# Altium schematic sheet Main.SchDoc
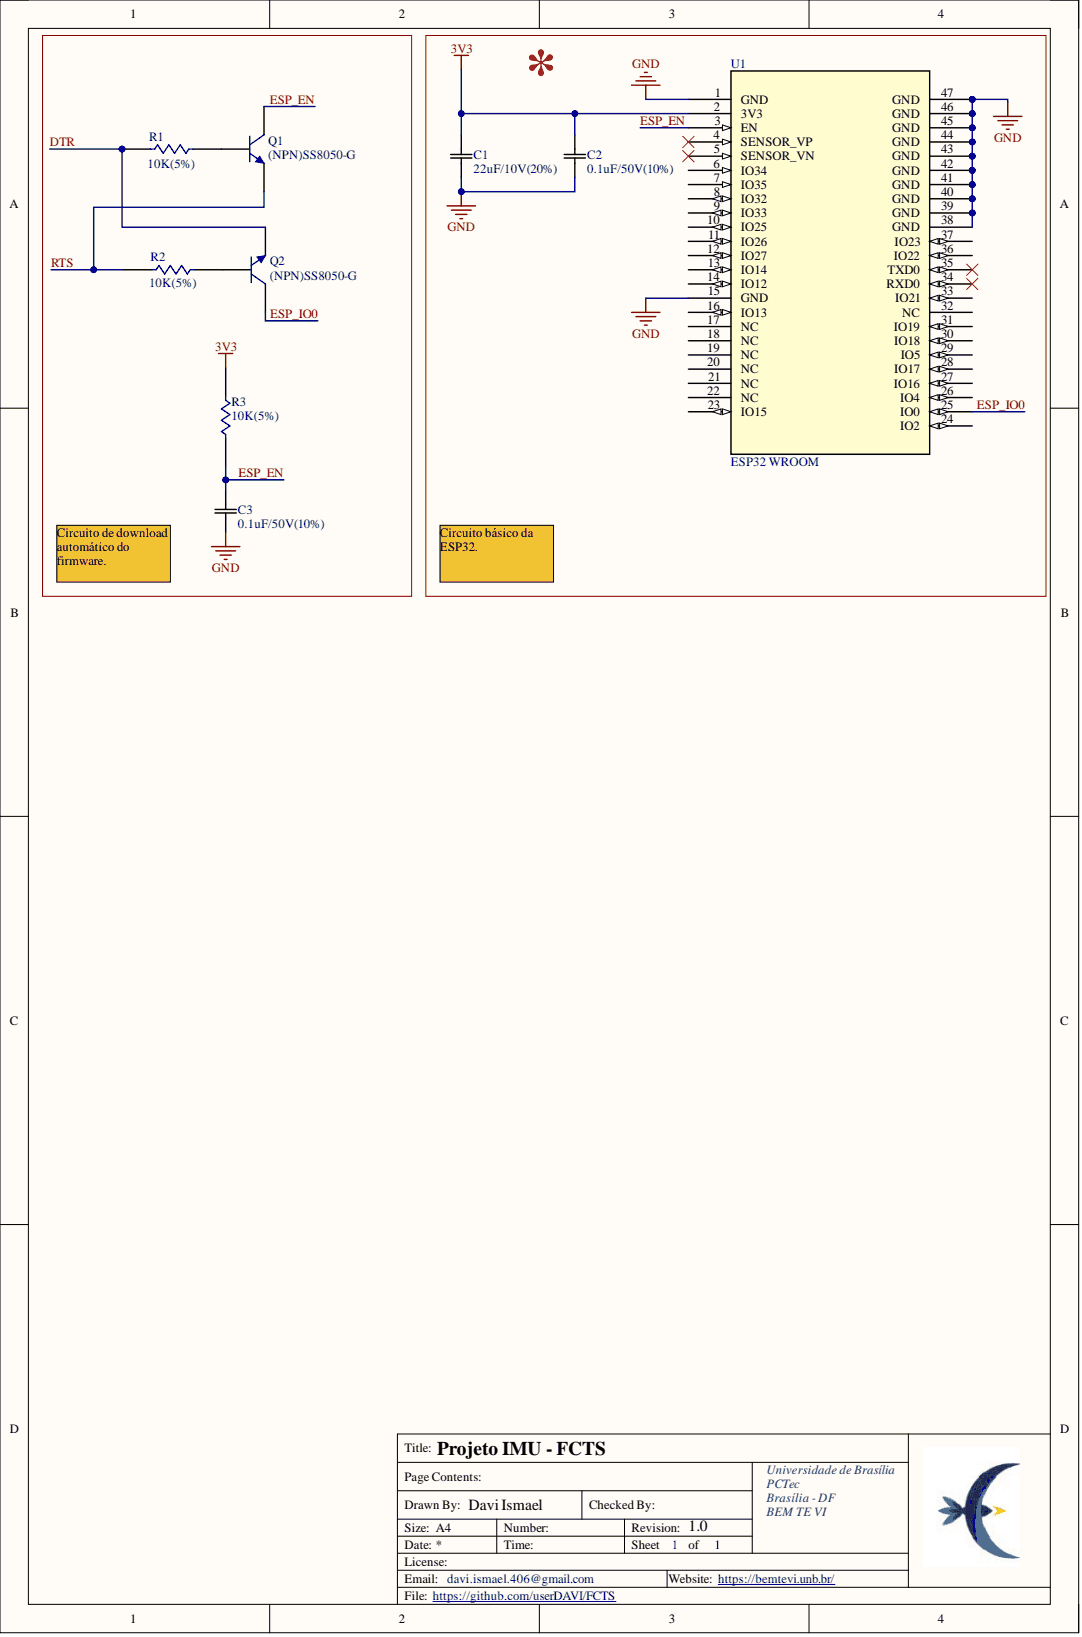
[diagram p0: Main.SchDoc]

## Parts
C1  22uF/10V(20%)  [CAP_LMK212B_2X1P25_TAY-M]
C2  0.1uF/50V(10%)  [CC0603N_YAG]
C3  0.1uF/50V(10%)  [CC0603N_YAG]
Q1  (NPN)SS8050-G  [(NPN)SS8050-G]
Q2  (NPN)SS8050-G  [(NPN)SS8050-G]
R1  10K(5%)  [STA_RMCF0603_STP-M]
R2  10K(5%)  [STA_RMCF0603_STP-M]
R3  10K(5%)  [STA_RMCF0603_STP-M]
U1  ESP32 WROOM  [MOD_ESP32-WROOM-32_EXP]

## Nets
3V3: C1.2 C2.2 R3.2 U1.2(3V3)
GND: C1.1 C2.1 C3.1 U1.1(GND) U1.15(GND) U1.38(GND) U1.39(GND) U1.40(GND) U1.41(GND) U1.42(GND) U1.43(GND) U1.44(GND) U1.45(GND) U1.46(GND) U1.47(GND)
DTR: Q2.3 R1.1
ESP_EN: C3.2 Q1.1 R3.1 U1.3(EN)
ESP_IO0: Q2.1 U1.25(IO0)
RTS: Q1.3 R2.1
NetQ1_2: Q1.2 R1.2
NetQ2_2: Q2.2 R2.2

Not connected: U1 4(SENSOR_VP) 5(SENSOR_VN) 6(IO34) 7(IO35) 8(IO32) 9(IO33) 10(IO25) 11(IO26) 12(IO27) 13(IO14) 14(IO12) 16(IO13) 17(NC) 18(NC) 19(NC) 20(NC) 21(NC) 22(NC) 23(IO15) 24(IO2) 26(IO4) 27(IO16) 28(IO17) 29(IO5) 30(IO18) 31(IO19) 32(NC) 33(IO21) 34(RXD0) 35(TXD0) 36(IO22) 37(IO23)

## Library data of the parts
C1 = LMK212BBJ226MG-T: No Description Available; Mfr_Name: Taiyo Yuden; Component Kind: Standard; Library Name: Integrated_Library1.IntLib; Supplier 1: Digikey; Supplier Part Number 1: 587-5464-1-ND
C2 C3 = CC0603KRX7R7BB104: No Description Available; Mfr_Name: Yageo; Component Kind: Standard; Library Name: Integrated_Library1.IntLib; Supplier 1: Future Electronics; Supplier Part Number 1: 2938116
Q1 Q2 = (NPN)SS8050-G: No Description Available; Mfr_Name: Comchip; Component Kind: Standard; Library Name: Integrated_Library1.IntLib; RefDes: RefDes; Type: Type
R1-R3 = RMCF0603JT10K0: No Description Available; Mfr_Name: Stackpole International; Component Kind: Standard; Library Name: Integrated_Library1.IntLib; Supplier 1: Digikey; Supplier Part Number 1: RMCF0603JT10K0CT-ND
U1 = ESP32 WROOM: Component Kind: Standard; Library Name: Integrated_Library1.IntLib; Supplier 1: Digikey; Supplier Part Number 1: 1904-ESP32-WROOM-32E(M113EH3200PH3Q0)TR-ND
